# Altium schematic sheet 01_Controller.SchDoc(01_Controller)
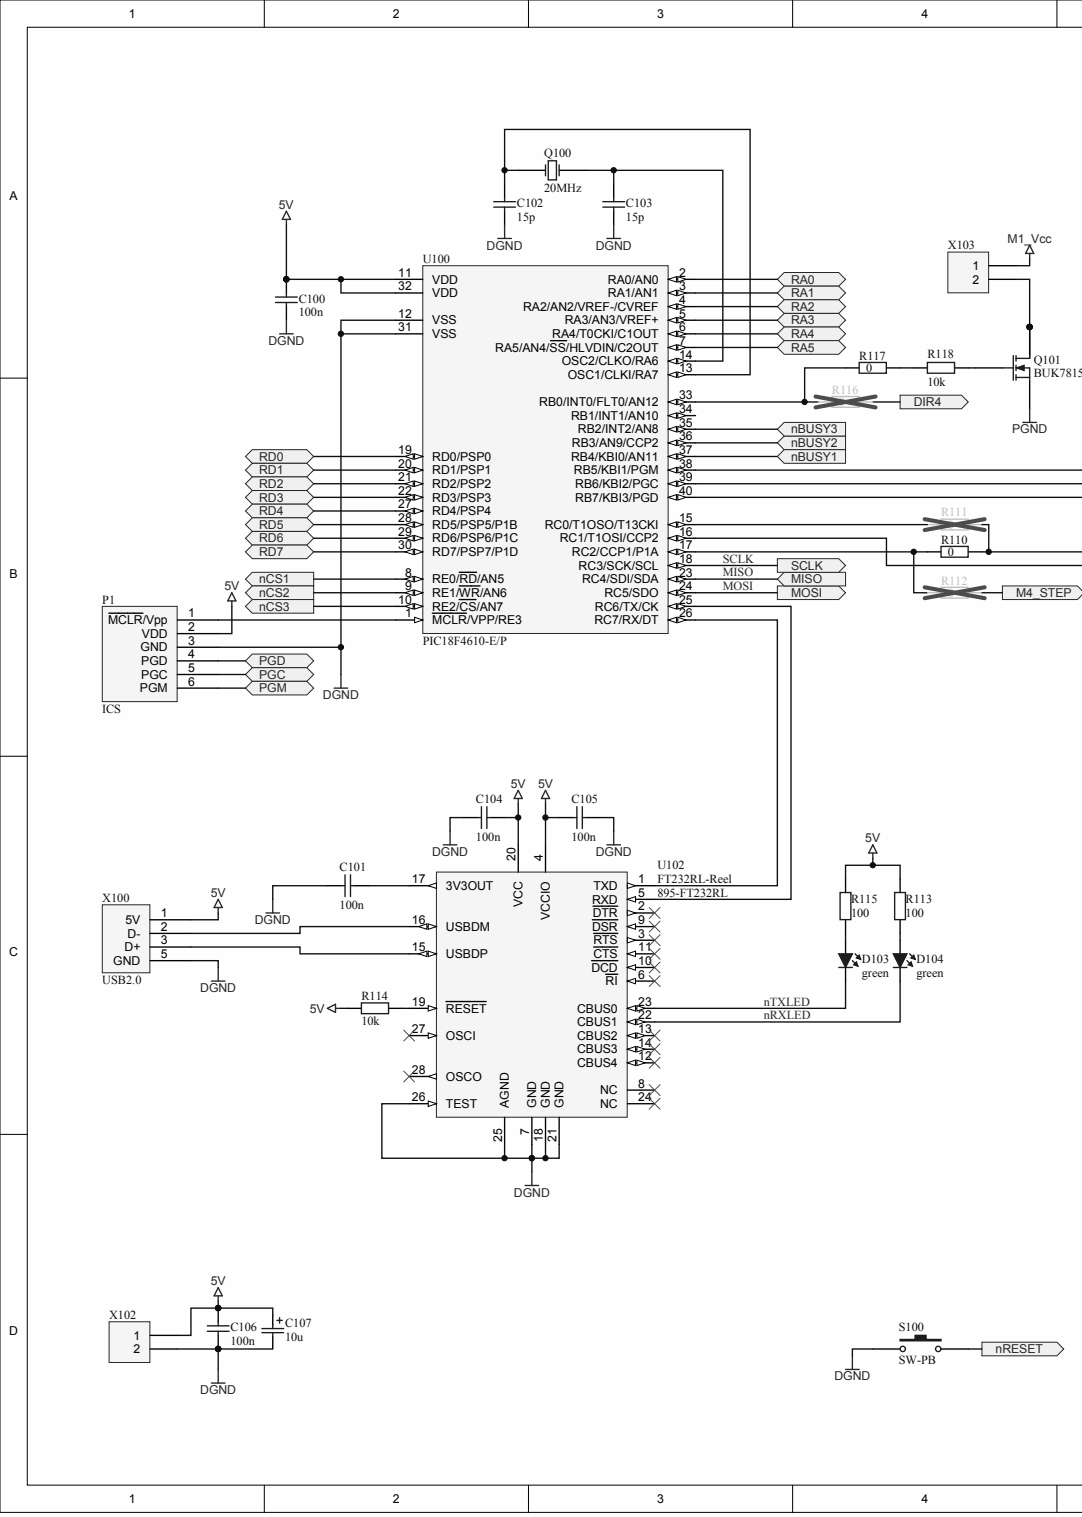
[diagram p0: 01_Controller.SchDoc, left]
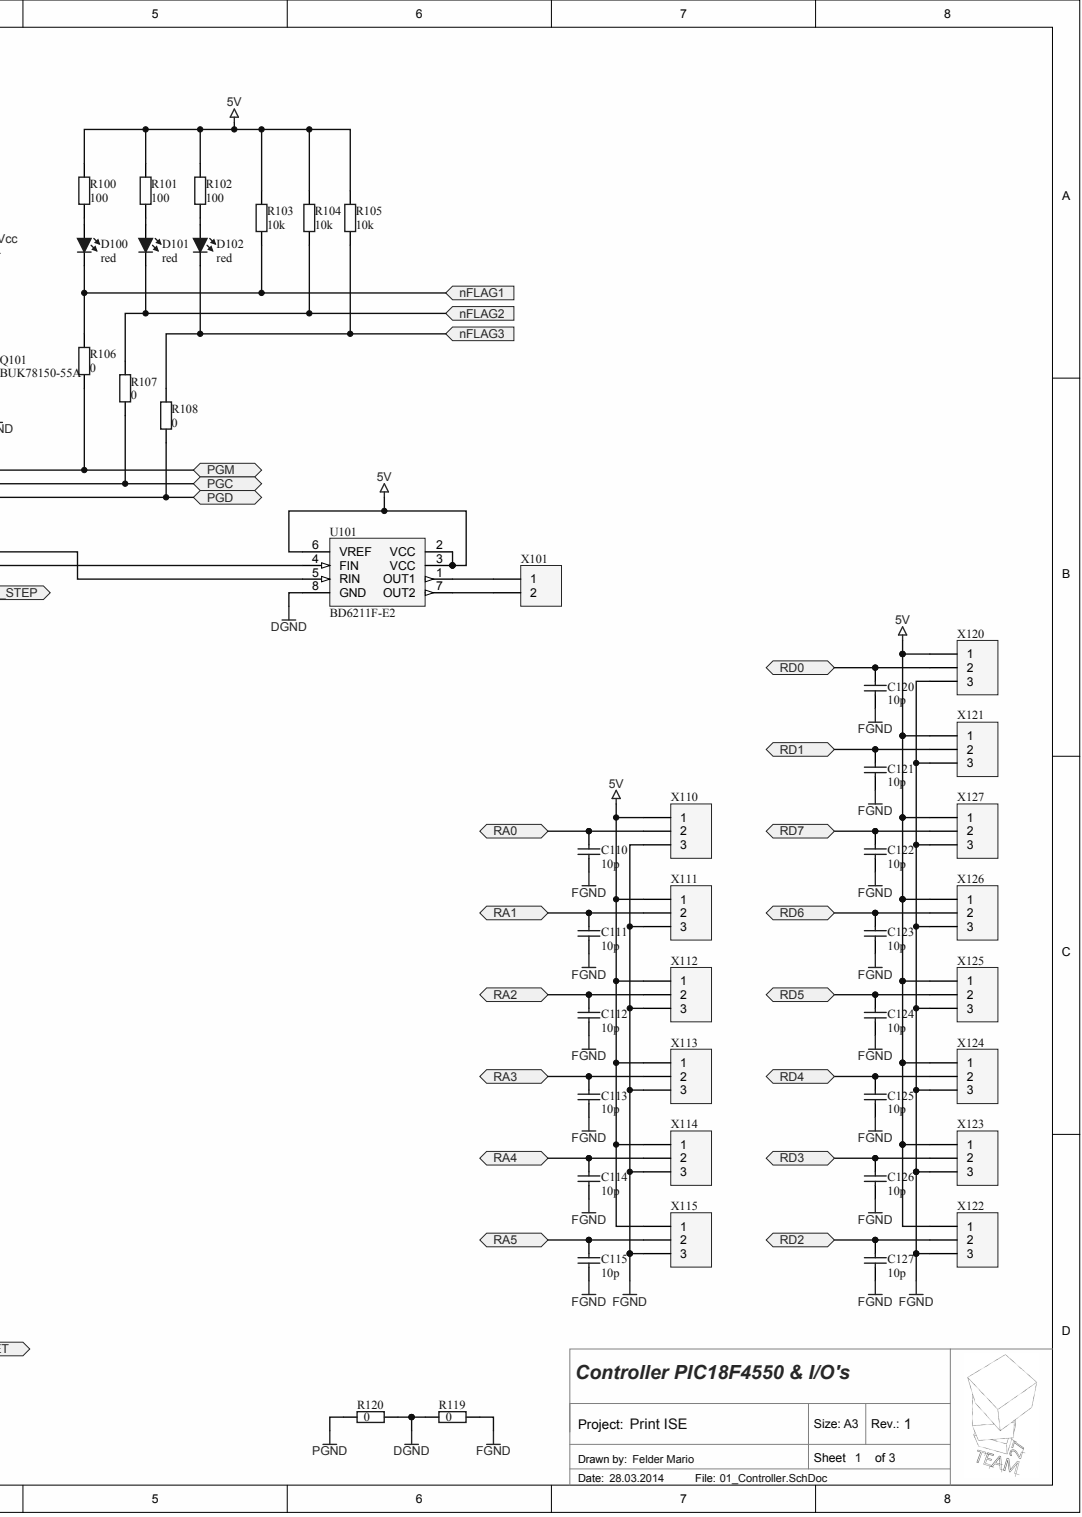
[diagram p1: 01_Controller.SchDoc, right]

## Parts
C100  100n
C101  100n
C102  15p
C103  15p
C104  100n
C105  100n
C106  100n
C107  10u
C110  10p
C111  10p
C112  10p
C113  10p
C114  10p
C115  10p
C120  10p
C121  10p
C122  10p
C123  10p
C124  10p
C125  10p
C126  10p
C127  10p
D100  red
D101  red
D102  red
D103  green
D104  green
P1  ICS
Q100  20MHz
Q101  BUK78150-55A
R100  100
R101  100
R102  100
R103  10k
R104  10k
R105  10k
R106  0
R107  0
R108  0
R110  0
R111  0
R112  0
R113  100
R114  10k
R115  100
R116  0
R117  0
R118  10k
R119  0
R120  0
S100  SW-PB
U100  PIC18F4610-E/P
U101  BD6211F-E2
U102  FT232RL-Reel  Supplier Part Number 1: 895-FT232RL
X100  USB2.0
X101  Header 2  (value not drawn)
X102  Header 2  (value not drawn)
X103  Header 2  (value not drawn)
X110  Header 3  (value not drawn)
X111  Header 3  (value not drawn)
X112  Header 3  (value not drawn)
X113  Header 3  (value not drawn)
X114  Header 3  (value not drawn)
X115  Header 3  (value not drawn)
X120  Header 3  (value not drawn)
X121  Header 3  (value not drawn)
X122  Header 3  (value not drawn)
X123  Header 3  (value not drawn)
X124  Header 3  (value not drawn)
X125  Header 3  (value not drawn)
X126  Header 3  (value not drawn)
X127  Header 3  (value not drawn)

## Ports
DIR4, M4_STEP, MISO, MOSI, NBUSY1, NBUSY2, NBUSY3, NCS1, NCS2, NCS3, NFLAG1, NFLAG2, NFLAG3, NRESET, PGC, PGD, PGM, RA0, RA1, RA2, RA3, RA4, RA5, RD0, RD1, RD2, RD3, RD4, RD5, RD6, RD7, SCLK

## Nets
5V: C100.2 C104.1 C105.2 C106.1 C107.1 P1.2(VDD) R100.2 R101.2 R102.2 R103.2 R104.2 R105.2 R113.2 R114.1 R115.2 U100.11(VDD) U100.32(VDD) U101.2(VCC) U101.3(VCC) U101.6(VREF) U102.4(VCCIO) U102.20(VCC) X100.1(5V) X102.1 X110.1 X111.1 X112.1 X113.1 X114.1 X115.1 X120.1 X121.1 X122.1 X123.1 X124.1 X125.1 X126.1 X127.1
DGND: C100.1 C101.2 C102.1 C103.1 C104.2 C105.1 C106.2 C107.2 P1.3(GND) R119.2 R120.2 S100.2 U100.12(VSS) U100.31(VSS) U101.8(GND) U102.7(GND) U102.18(GND) U102.21(GND) U102.25(AGND) U102.26(TEST) X100.5(GND) X102.2
FGND: C110.1 C111.1 C112.1 C113.1 C114.1 C115.1 C120.1 C121.1 C122.1 C123.1 C124.1 C125.1 C126.1 C127.1 R119.1 X110.3 X111.3 X112.3 X113.3 X114.3 X115.3 X120.3 X121.3 X122.3 X123.3 X124.3 X125.3 X126.3 X127.3
M1_VCC: X103.1
PGND: Q101.3 R120.1
DIR4: R116.1 ; port DIR4
M4_STEP: R112.1 ; port M4_STEP
MISO: U100.23(RC4/SDI/SDA) ; port MISO
MOSI: U100.24(RC5/SDO) ; port MOSI
NRXLED: D104.2 U102.22(CBUS1)
NTXLED: D103.2 U102.23(CBUS0)
PGC: P1.5(PGC) R107.1 U100.39(RB6/KBI2/PGC) ; port PGC
PGD: P1.4(PGD) R108.1 U100.40(RB7/KBI3/PGD) ; port PGD
PGM: P1.6(PGM) R106.1 U100.38(RB5/KBI1/PGM) ; port PGM
RA0: C110.2 U100.2(RA0/AN0) X110.2 ; port RA0
RA1: C111.2 U100.3(RA1/AN1) X111.2 ; port RA1
RA2: C112.2 U100.4(RA2/AN2/VREF-/CVREF) X112.2 ; port RA2
RA3: C113.2 U100.5(RA3/AN3/VREF+) X113.2 ; port RA3
RA4: C114.2 U100.6(RA4/T0CKI/C1OUT) X114.2 ; port RA4
RA5: C115.2 U100.7(RA5/AN4/SS/HLVDIN/C2OUT) X115.2 ; port RA5
RD0: C120.2 U100.19(RD0/PSP0) X120.2 ; port RD0
RD1: C121.2 U100.20(RD1/PSP1) X121.2 ; port RD1
RD2: C127.2 U100.21(RD2/PSP2) X122.2 ; port RD2
RD3: C126.2 U100.22(RD3/PSP3) X123.2 ; port RD3
RD4: C125.2 U100.27(RD4/PSP4) X124.2 ; port RD4
RD5: C124.2 U100.28(RD5/PSP5/P1B) X125.2 ; port RD5
RD6: C123.2 U100.29(RD6/PSP6/P1C) X126.2 ; port RD6
RD7: C122.2 U100.30(RD7/PSP7/P1D) X127.2 ; port RD7
SCLK: U100.18(RC3/SCK/SCL) ; port SCLK
NetC101_1: C101.1 U102.17(3V3OUT)
NetC102_2: C102.2 Q100.1 U100.13(OSC1/CLKI/RA7)
NetC103_2: C103.2 Q100.2 U100.14(OSC2/CLKO/RA6)
NetD100_1: D100.1 R100.1
NetD100_2: D100.2 R103.1 R106.2 ; port NFLAG1
NetD101_1: D101.1 R101.1
NetD101_2: D101.2 R104.1 R107.2 ; port NFLAG2
NetD102_1: D102.1 R102.1
NetD102_2: D102.2 R105.1 R108.2 ; port NFLAG3
NetD103_1: D103.1 R115.1
NetD104_1: D104.1 R113.1
NetP1_1: P1.1(MCLR/Vpp) U100.1(MCLR/VPP/RE3)
NetQ101_1: Q101.1 Q101.4 X103.2
NetQ101_2: Q101.2 R118.1
NetR110_1: R110.1 R111.1 U101.5(RIN)
NetR110_2: R110.2 R112.2 U100.17(RC2/CCP1/P1A)
NetR111_2: R111.2 U100.15(RC0/T1OSO/T13CKI)
NetR114_2: R114.2 U102.19(RESET)
NetR116_2: R116.2 R117.2 U100.33(RB0/INT0/FLT0/AN12)
NetR117_1: R117.1 R118.2
NetS100_3: S100.3 ; port NRESET
NetU100_8: U100.8(RE0/RD/AN5) ; port NCS1
NetU100_9: U100.9(RE1/WR/AN6) ; port NCS2
NetU100_10: U100.10(RE2/CS/AN7) ; port NCS3
NetU100_16: U100.16(RC1/T1OSI/CCP2) U101.4(FIN)
NetU100_25: U100.25(RC6/TX/CK) U102.5(RXD)
NetU100_26: U100.26(RC7/RX/DT) U102.1(TXD)
NetU100_35: U100.35(RB2/INT2/AN8) ; port NBUSY3
NetU100_36: U100.36(RB3/AN9/CCP2) ; port NBUSY2
NetU100_37: U100.37(RB4/KBI0/AN11) ; port NBUSY1
NetU101_1: U101.1(OUT1) X101.1
NetU101_7: U101.7(OUT2) X101.2
NetU102_15: U102.15(USBDP) X100.3(D+)
NetU102_16: U102.16(USBDM) X100.2(D-)

Not connected: U100 34(RB1/INT1/AN10); U102 2(DTR) 3(RTS) 6(RI) 8(NC) 9(DSR) 10(DCD) 11(CTS) 12(CBUS4) 13(CBUS2) 14(CBUS3) 24(NC) 27(OSCI) 28(OSCO)

## Library data of the parts
C107 = (no symbol name): Value: 100pF; Published: 8-Jun-2000; LatestRevisionDate: 17-Jul-2002; LatestRevisionNote: Re-released for DXP Platform.; PackageReference: POLAR0.8; PackageDescription: Polarized Capacitor; 2 Leads; Publisher: Altium Limited
C100-C106 C110-C115 C120-C127 D100-D104 P1 Q100 Q101 R100-R108 R110-R120 S100 U100-U102 X100-X103 X110-X115 X120-X127 = (no symbol name)
  C100-C106 C110-C115 C120-C127: PackageReference: 0805
  D100-D102: PackageReference: 0603; PackageDescription: SMD LED 06063; Supplier 1: Mouser; Supplier Part Number 1: 78-VLMS1300-GS08
  D103 D104: PackageReference: 0603; PackageDescription: SMD LED 06063; Supplier 1: Mouser; Supplier Part Number 1: 78-VLMTG1300-GS08
  Q100: Supplier 1: Mouser; Supplier Part Number 1: 774-ATS200CSM-1
  Q101: PackageReference: SOT-223; Supplier 1: Mouser; Supplier Part Number 1: 771-BUK78150-55A115
  R100-R108 R110-R120: PackageReference: 0805; PackageDescription: SMD
  U100: Published: 29-Jan-2009; LatestRevisionDate: 02-Dec-2009; LatestRevisionNote: Updated to datasheet revision D, Oct-2009; DatasheetVersion: revD, Oct-2009; PackageVersion: revBB, Aug-2009; PackageReference: PDIP600-P40; PackageDescription: 40-Lead Plastic Dual In-Line (P) - 600 mil Body [PDIP]; Supplier 1: Digi-Key; Supplier Part Number 1: PIC18F4610-E/P-ND; ComponentLink1Description: Datasheet; ComponentLink1URL: http://ww1.microchip.com/downloads/en/DeviceDoc/39636d.pdf; ComponentLink2Description: Packaging Specification; ComponentLink2URL: http://www.microchip.com/stellent/groups/techpub_sg/documents/packagingspec/en012702.pdf; ComponentLink3Description: Microchip.com Home; ComponentLink3URL: http://www.microchip.com/
  U101: PackageReference: SOP-8; Supplier 1: Mouser; Supplier Part Number 1: 755-BD6211F-E2
  U102: PackageReference: SSOP-28; PackageDescription: 28-Pin Small Outline Package 0.65 mm Pitch; Supplier 1: Mouser
  X100: Supplier 1: Mouser; Supplier Part Number 1: 649-10118193-0001LF
  X101-X103 X110-X115 X120-X127: LatestRevisionDate: 17-Jul-2002; LatestRevisionNote: Re-released for DXP Platform.
  U100 X101-X103 X110-X115 X120-X127: Publisher: Altium Limited
